# Altium schematic sheet SignalBufferBoard.SchDoc
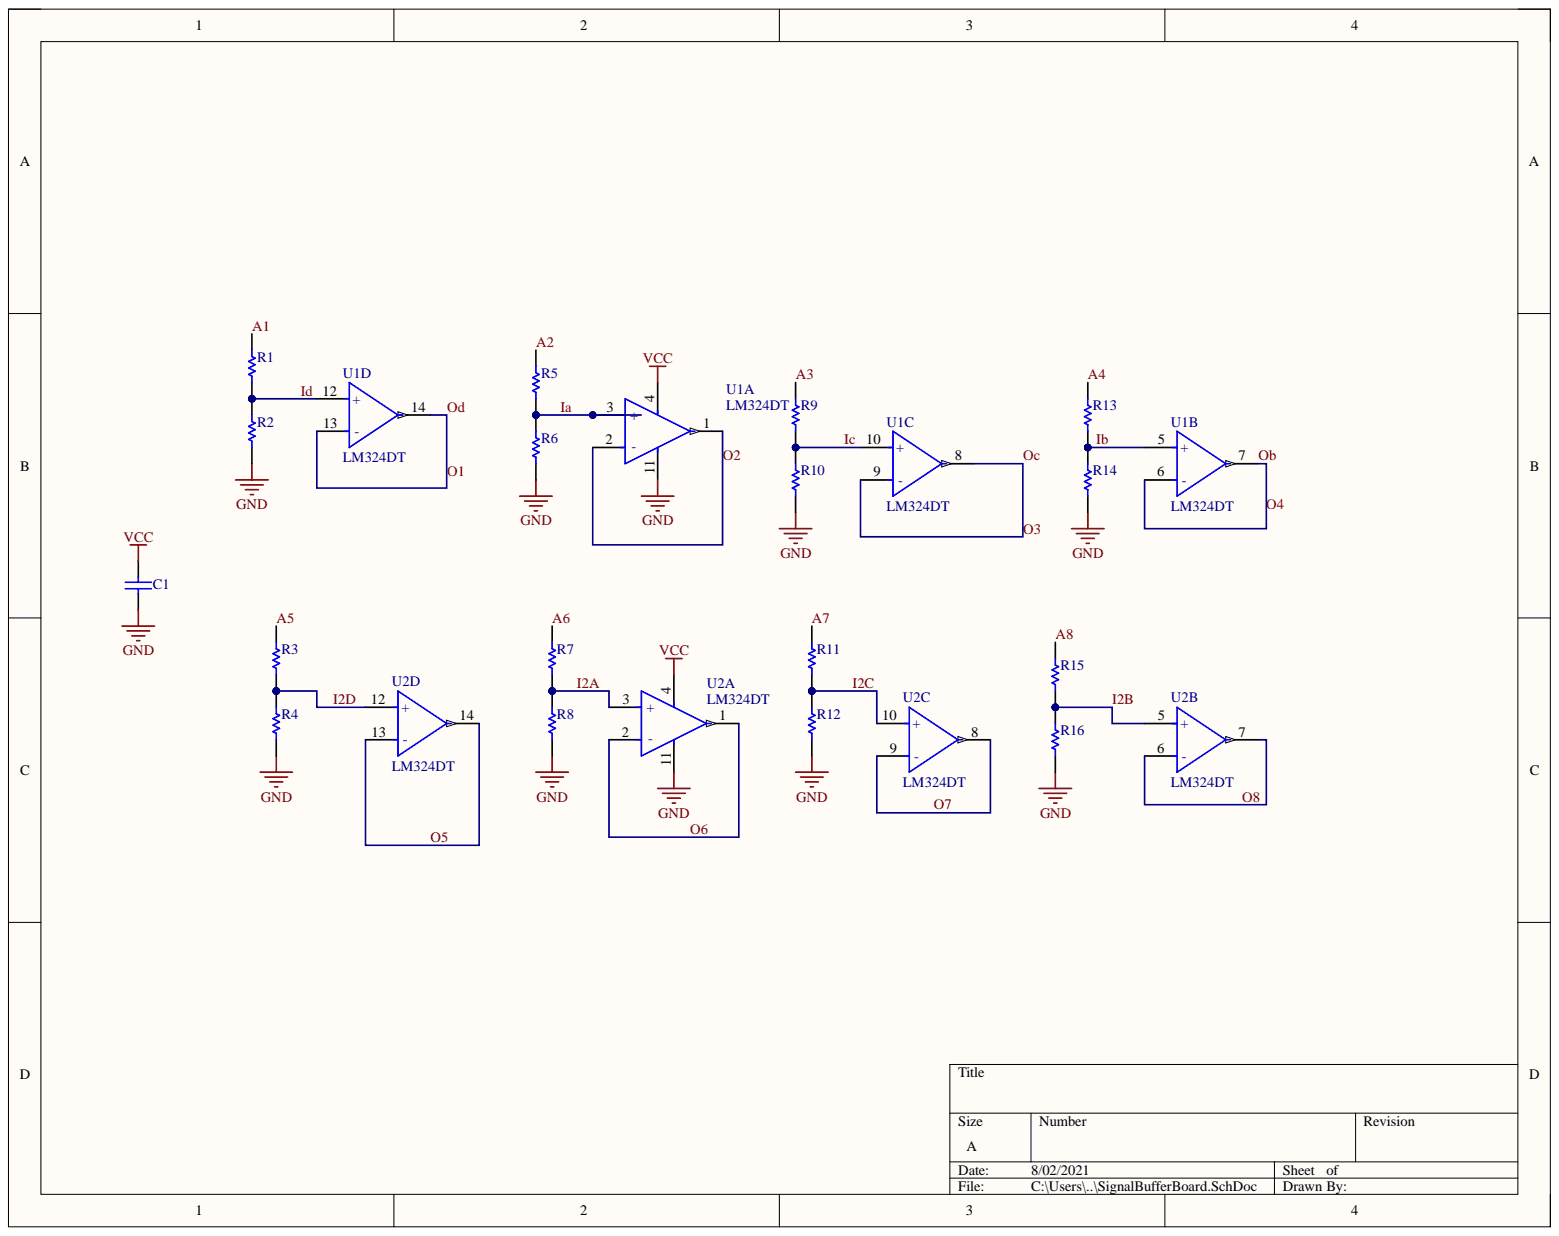
[diagram p0: SignalBufferBoard.SchDoc]

## Parts
C1  C0805C105K4PACTU  [CAPC2013X145X50NL20T25]  (value not drawn)
R1  ERA6AEB104V  [RESC2013X60X40ML20T25]  (value not drawn)
R2  ERA6AEB204V  [RESC2013X60X40ML20T25]  (value not drawn)
R3  ERA6AEB104V  [RESC2013X60X40ML20T25]  (value not drawn)
R4  ERA6AEB204V  [RESC2013X60X40ML20T25]  (value not drawn)
R5  ERA6AEB104V  [RESC2013X60X40ML20T25]  (value not drawn)
R6  ERA6AEB204V  [RESC2013X60X40ML20T25]  (value not drawn)
R7  ERA6AEB104V  [RESC2013X60X40ML20T25]  (value not drawn)
R8  ERA6AEB204V  [RESC2013X60X40ML20T25]  (value not drawn)
R9  ERA6AEB104V  [RESC2013X60X40ML20T25]  (value not drawn)
R10  ERA6AEB204V  [RESC2013X60X40ML20T25]  (value not drawn)
R11  ERA6AEB104V  [RESC2013X60X40ML20T25]  (value not drawn)
R12  ERA6AEB204V  [RESC2013X60X40ML20T25]  (value not drawn)
R13  ERA6AEB104V  [RESC2013X60X40ML20T25]  (value not drawn)
R14  ERA6AEB204V  [RESC2013X60X40ML20T25]  (value not drawn)
R15  ERA6AEB104V  [RESC2013X60X40ML20T25]  (value not drawn)
R16  ERA6AEB204V  [RESC2013X60X40ML20T25]  (value not drawn)
U1  LM324DT  [FP-SO-14-IPC_A]  (parts A,B,C,D)
U2  LM324DT  [FP-SO-14-IPC_A]  (parts A,B,C,D)

## Nets
GND: C1.1 R2.1 R4.1 R6.1 R8.1 R10.1 R12.1 R14.1 R16.1 U1.11 U2.11
VCC: C1.2 U1.4 U2.4
A1: R1.2
A2: R5.2
A3: R9.2
A4: R13.2
A5: R3.2
A6: R7.2
A7: R11.2
A8: R15.2
I2A: R7.1 R8.2 U2.3(+)
I2B: R15.1 R16.2 U2.5(+)
I2C: R11.1 R12.2 U2.10(+)
I2D: R3.1 R4.2 U2.12(+)
Ia: R5.1 R6.2 U1.3(+)
Ib: R13.1 R14.2 U1.5(+)
Ic: R9.1 R10.2 U1.10(+)
Id: R1.1 R2.2 U1.12(+)
O1: U1.13(-) U1.14
O2: U1.1 U1.2(-)
O3: U1.8 U1.9(-)
O4: U1.6(-) U1.7
O5: U2.13(-) U2.14
O6: U2.1 U2.2(-)
O7: U2.8 U2.9(-)
O8: U2.6(-) U2.7

## Library data of the parts
C1 = CMP-1036-04746-2: Manufacturer: Kemet; Component Kind: Standard; Library Name: Altium Content Vault; Part Number: C0805C105K4PACTU
R1 R3 R5 R7 R9 R11 R13 R15 = CMP-2001-00583-1: Manufacturer: Panasonic; Component Kind: Standard; Library Name: Altium Content Vault; Part Number: ERA6AEB104V
R2 R4 R6 R8 R10 R12 R14 R16 = CMP-2001-00611-1: Manufacturer: Panasonic; Component Kind: Standard; Library Name: Altium Content Vault; Part Number: ERA6AEB204V
U1 U2 = CMP-12131-000005-1: IC OPAMP GP 4 CIRCUIT 14SO; Manufacturer: STMicroelectronics; Bandwidth: 1.3MHz; Input Bias Current: 0.02uA; Input Offset Voltage: 2mV; Max Dual Supply Voltage: 15V; Max Junction Temp: +150°C; Max Supply Voltage: 30V; Min Dual Supply Voltage: 1.5V; Min Supply Voltage: 3V; Nominal Supply Current: 0.7-1.5mA; Number Of Channels: 4; Output Current Per Channel: 20-50mA; Standoff Height: 0.1mm; Voltage Gain: 100dB; Automotive: No; Category: IC; Component Kind: Standard; ComponentLink2Description: Datasheet; ComponentLink2URL: https://www.st.com/content/ccc/resource/technical/document/datasheet/bd/fc/46/43/26/8f/40/7f/CD00001046.pdf/files/CD00001046.pdf/jcr:content/translations/en.CD00001046.pdf; Device Class L1: Integrated Circuits (ICs); Device Class L2: Linear ICs; Device Class L3: Amplifiers - Op Amps; Digikey Description: IC OPAMP GP 4 CIRCUIT 14SO; Footprint Url: https://www.st.com/content/ccc/resource/technical/document/datasheet/group3/10/8a/e6/12/f8/e8/49/ce/DM00366438/files/DM00366438.pdf/jcr:content/translations/en.DM00366438.pdf; Gain Bandwidth: 1.3MHz; Height: 1.75mm; Lead Free: Yes; Library Name: Altium Content Vault; Manufacturer Part Number: LM324DT; Mouser Description: LM324 Series 32 V 1.3 MHz Low Power Quad Operational Amplifier - SOIC-14; Octopart: https://octopart.com/lm324dt-stmicroelectronics-39579146; Package: SO-14; RoHS: TRUE; Temperature: 0°C to +70°C; Temperature Range High: +70°C; Temperature Range Low: 0°C
